# Altium schematic sheet MCU.SchDoc
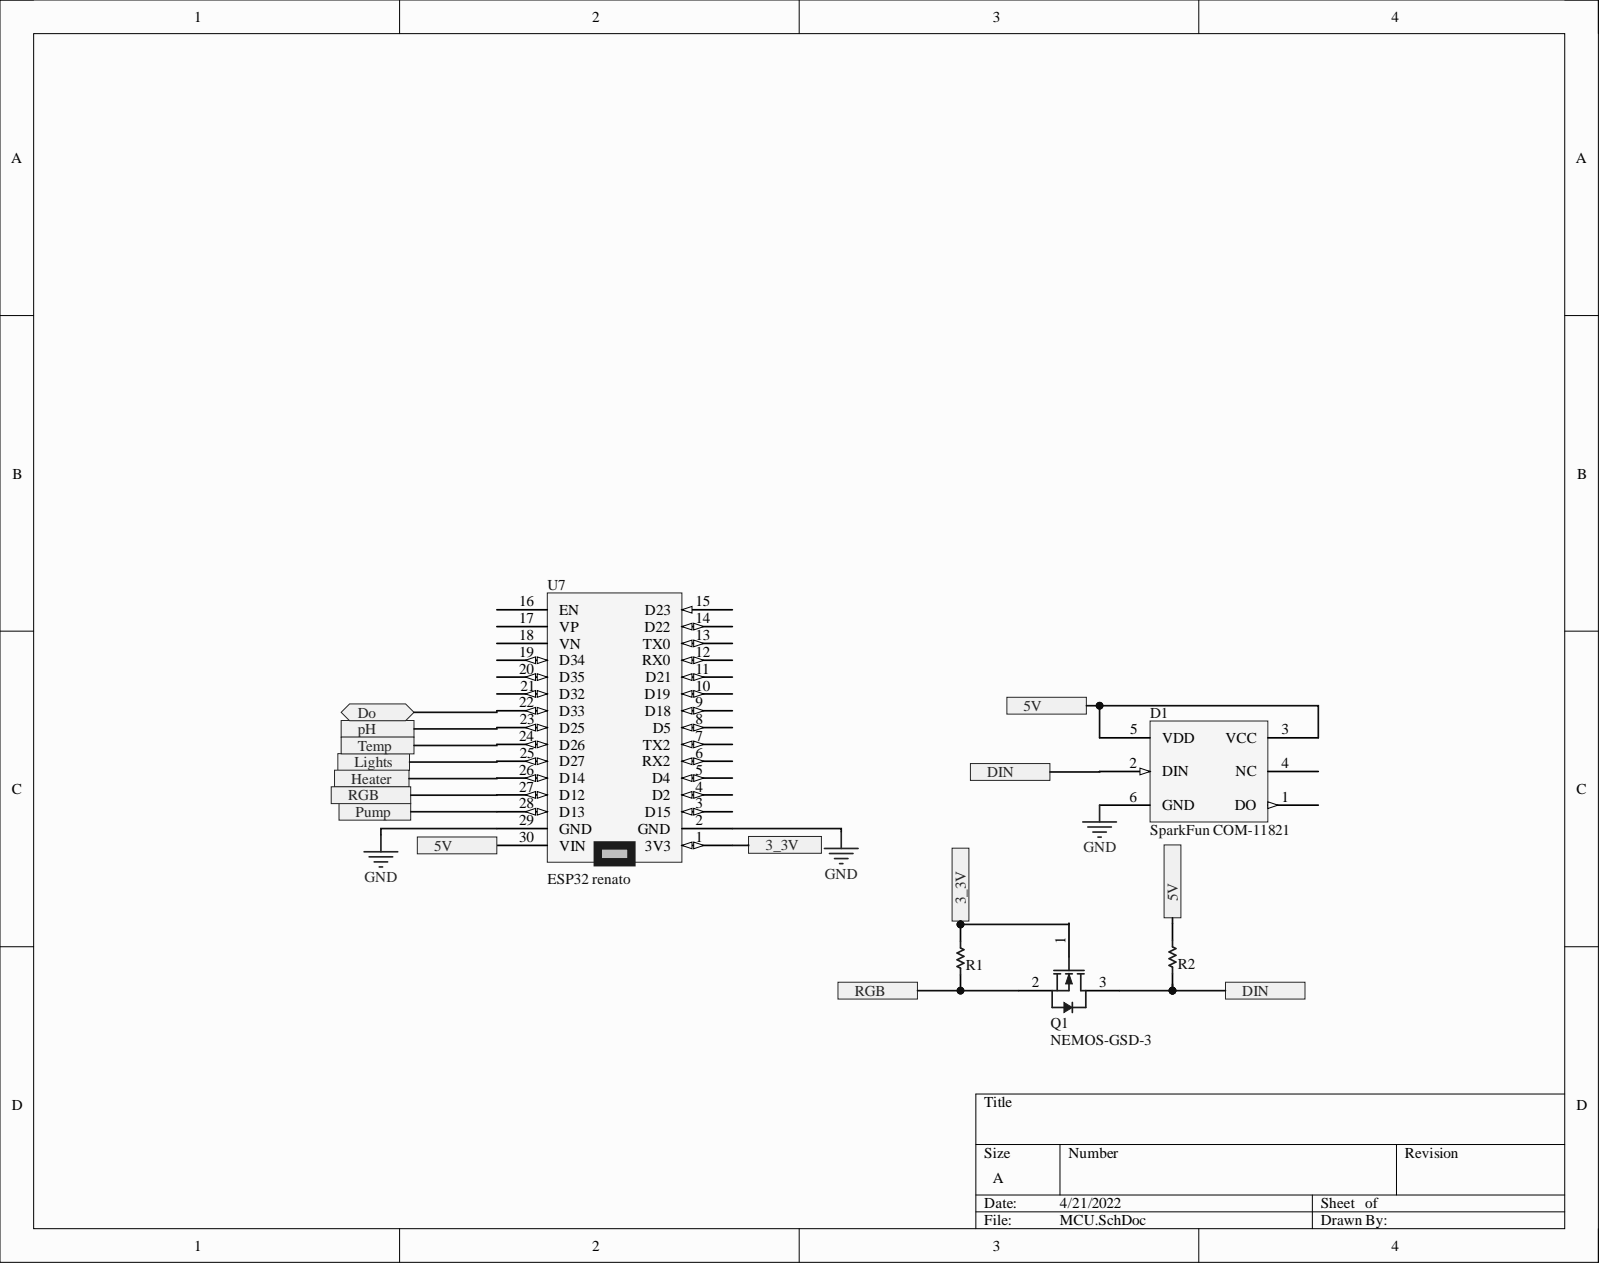
[diagram p0: MCU.SchDoc]

## Parts
D1  SparkFun COM-11821  [PCB-1ur4ffa4apwrigk38oa4-1]
Q1  NEMOS-GSD-3  [PCB-dbhk9q13wds7exg38ggf-1]
R1  YAGEO RC0805JR-072KL  [PCB-tn648mo2y0oen0ikj7ny-1]  (value not drawn)
R2  YAGEO RC0805JR-072KL  [PCB-tn648mo2y0oen0ikj7ny-1]  (value not drawn)
U7  ESP32 renato  [ESP32]

## Ports
3_3V, 5V, DIN, Do, Heater, Lights, pH, Pump, RGB, Temp

## Nets
GND: D1.6(GND) U7.2(GND) U7.29(GND)
3_3V: Q1.1 R1.2 U7.1(3V3) ; port 3_3V
5V: D1.3(VCC) D1.5(VDD) R2.2 U7.30(VIN) ; port 5V
DIN: D1.2(DIN) Q1.3 R2.1 ; port DIN
Do: U7.22(D33) ; port Do
Heater: U7.26(D14) ; port Heater
Lights: U7.25(D27) ; port Lights
pH: U7.23(D25) ; port pH
Pump: U7.28(D13) ; port Pump
RGB: Q1.2 R1.1 U7.27(D12) ; port RGB
Temp: U7.24(D26) ; port Temp

Not connected: D1 1(DO) 4(NC); U7 3(D15) 4(D2) 5(D4) 6(RX2) 7(TX2) 8(D5) 9(D18) 10(D19) 11(D21) 12(RX0) 13(TX0) 14(D22) 15(D23) 16(EN) 17(VP) 18(VN) 19(D34) 20(D35) 21(D32)

## Library data of the parts
D1 = CMP-ba0777713b8065c0-3: Led - Smd Rgb (Ws2812); Component Kind: Standard; Library Name: CommunityVault; OctopartID: ba0777713b8065c0
Q1 = CMP-2868e1aa5c2af7af-8: Transistor NPN Mos BSS138 FAIRCHILD RoHS miliampere=220 V=50 SOt23; Manufacturer: Fairchild Semiconductor; Breakdown Voltage (Drain to Source): 50.0 V; Breakdown Voltage [Gate to Source]: -20.0 V to 20.0 V; Continuous Drain Current (Ids): 220 mA; Drain to Source Resistance (on) (Rds): 3.50 Ω; Input Capacitance: 27.0 pF; Lead-Free Status: Lead Free; Polarity: N-Channel; Case/Package: SOT-23; Component Kind: Standard; Library Name: CommunityVault; Lifecycle Status: Active; Manufacturer Part Number: BSS138; Number of Pins: 3; Octopart URL: http://octopart.com/bss138-fairchild+semiconductor-13127972; Packaging: Cut Tape (CT), Tape & Reel (TR); Voltage Rating (DC): 50.0 V
R1 R2 = CMP-1854011-4: RC Series 0805 0.125 W 2 kOhms 5% ±100 ppm/°C SMT Thick Film Chip Resistor; Case/Package (SI): 2012; Lead-Free Status: Lead Free; Power Rating: 0.125 W; Resistance: 10k; Voltage Rating (DC): 150.0 V; Case/Package: 2012 SI; Component Kind: Standard; Library Name: My Personal Space; Mounting Style: Surface Mount; Number of Pins: 2; Octopart Url: http://octopart.com/rc0805jr-072kl-yageo-1251295; OctopartID: 57629e7b65db88a5; Resistance Tolerance: ±5%; RoHS: Compliant; Seller Landing  Url: https://octopart-clicks.com/click/track?country=US&ak=8157f166&sig=0739e0e&sid=2402&ppid=1251295&vpid=121062726&ct=offers; Size-Height: 0.0005 m; Size-Length: 0.002 m; Size-Width: 0.00125 m
U7 = CMP-63e8ae3c0f9dbc2f-12: ESP32 NODEMCU 30GPIO version; Component Kind: Net Tie; Library Name: CommunityVault
